# Altium schematic sheet Stage1A_Boost_controller.SchDoc
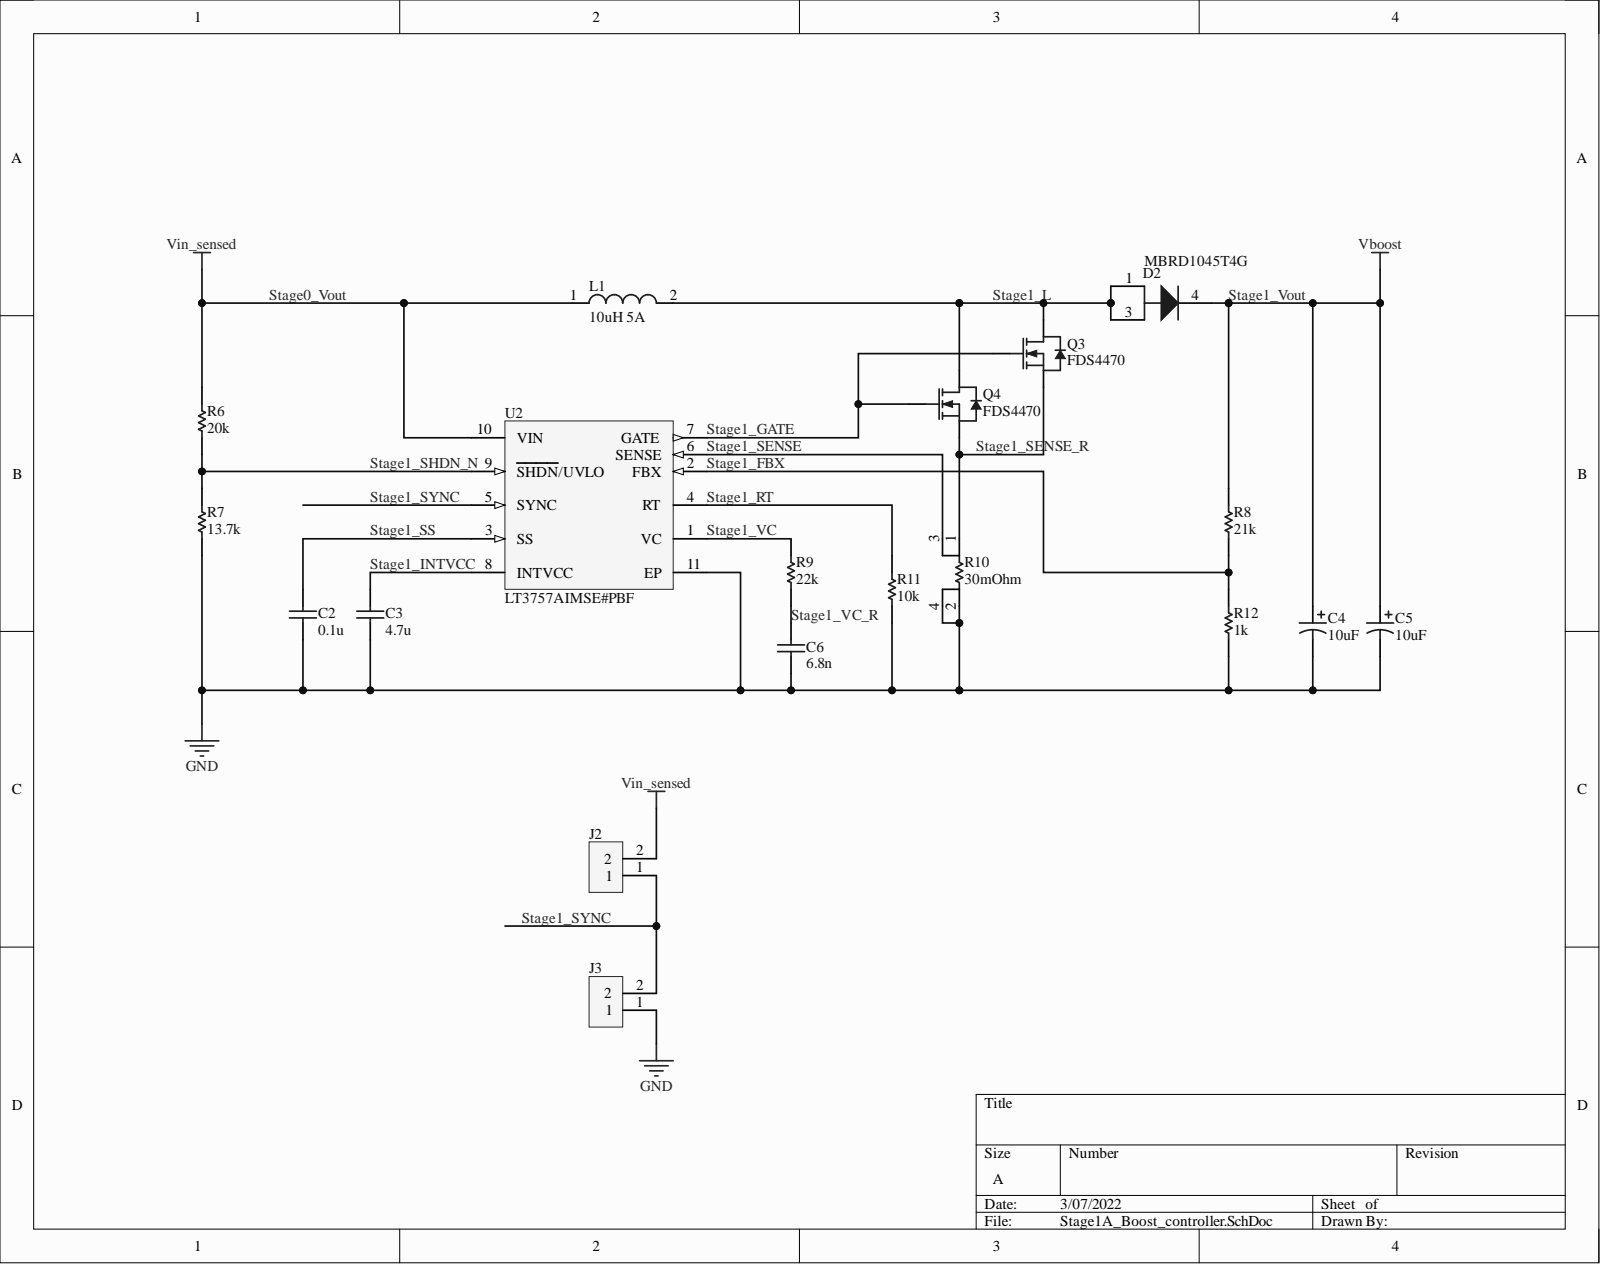
[diagram p0: Stage1A_Boost_controller.SchDoc]

## Parts
C2  0.1u  [PCB-0002-00735-1]
C3  4.7u  [CAPC2013X94X50ML20T25]
C4  10uF  [PCB-45uljczbzgwzo9m7ql45-1]
C5  10uF  [PCB-45uljczbzgwzo9m7ql45-1]
C6  6.8n  [CAPC2013X88X50NL20T25]
D2  MBRD1045T4G  [ONSC-DPAK-3-369C-01_V]
J2  (no value)  [PCB-omgsbkhp90n7lpri88og-1]
J3  (no value)  [PCB-omgsbkhp90n7lpri88og-1]
L1  10uH 5A  [WE-PD-L]
Q3  FDS4470  [PCB-vam1no1ldi8601k0q85a-1]
Q4  FDS4470  [PCB-vam1no1ldi8601k0q85a-1]
R6  20k  [RESC2013X55X40LL15T20]
R7  13.7k  [RESC2013X70X40LL20T20]
R8  21k  [RESC2013X70X40NL20T20]
R9  22k  [PCB-0002-00354-1]
R10  30mOhm  [PCB-e4z1ou8eehx9zfei5nig-1]
R11  10k  [RESC2013X55X40NL15T20]
R12  1k  [PCB-r6i24v81vhbjsehgeff4-1]
U2  LT3757AIMSE#PBF  [LT-MSE-10-EDP_N]

## Nets
GND: C2.1 C3.1 C4.2 C5.2 C6.1 J3.1 R7.1 R10.2 R10.4 R11.1 R12.1 U2.11(EP)
Stage0_Vout: J2.2 L1.1 R6.2 U2.10(VIN)
Stage1_FBX: R8.1 R12.2 U2.2(FBX)
Stage1_GATE: Q3.1 Q4.1 U2.7(GATE)
Stage1_INTVCC: C3.2 U2.8(INTVCC)
Stage1_L: D2.1 D2.3 L1.2 Q3.3 Q4.3
Stage1_RT: R11.2 U2.4(RT)
Stage1_SENSE: R10.3 U2.6(SENSE)
Stage1_SENSE_R: Q3.2 Q4.2 R10.1
Stage1_SHDN_N: R6.1 R7.2 U2.9(SHDN/UVLO)
Stage1_SS: C2.2 U2.3(SS)
Stage1_SYNC: J2.1 J3.2 U2.5(SYNC)
Stage1_VC: R9.2 U2.1(VC)
Stage1_VC_R: C6.2 R9.1
Stage1_Vout: C4.1 C5.1 D2.4 R8.2

## Library data of the parts
C2 = CMP-126393-3: CAP CER 0.1UF 50V 10% X7R 0805; Manufacturer Part Number: 08055C104KAZ2A; Capacitance: 1e-07 F; Case/Package (SI): 2012; Dielectric Material: Ceramic Multilayer; Lead-Free Status: Lead Free; Voltage Rating (DC): 50.0 V; Capacitance Tolerance: ±10%; Case/Package: 0805; Component Kind: Standard; Dielectric Characteristic: X7R; Library Name: My Personal Space; Mounting Style: Surface Mount; Number of Pins: 2; Octopart Url: https://octopart.com/08055c104kaz2a-avx+interconnect+%2F+elco-195624; OctopartID: aa35633d737b75f2; REACH SVHC Compliance: No SVHC; RoHS: Compliant; Size-Height: 0.00094 m; Size-Length: 0.00201 m; Size-Width: 0.00125 m
C3 = CMP-a5e66d676ec67a21-1: C Series 0805 4.7 uF 25 V ±10 % Tolerance X5R SMT Multilayer Ceramic Capacitor; Manufacturer Part Number: C2012X5R1E475K125AB; Capacitance: 4.70 µF; Capacitance Tolerance: ±10%; Dielectric Material: Ceramic Multilayer; Lead-Free Status: Lead Free; Voltage Rating (DC): 25.0 V; Case/Package: 2012; Component Kind: Standard; Dielectric Characteristic: X5R; Library Name: My Personal Space; Number of Pins: 2; Octopart URL: http://octopart.com/c2012x5r1e475k125ab-tdk-25947718; OctopartID: a5e66d676ec67a21; Packaging: Cut Tape (CT); REACH SVHC Compliance: No SVHC; RoHS: Compliant; Size-Length: 2.00 mm; Size-Thickness: 1.25 mm; Size-Width: 1.25 mm
C4 C5 = CMP-7610bd40367da9d7-6: Capacitor; Aluminum Electrolytic; Fc Radial Elec Cap 10uF 50V; Manufacturer Part Number: EEU-FC1H100L; Capacitance: 10.0 µF; Capacitance Tolerance: ±20%; Lead-Free Status: Lead Free; Voltage Rating (DC): 50.0 V; Component Kind: Standard; Dielectric Material: Aluminum Electrolytic; Library Name: My Personal Space; Lifecycle Status: Not Listed by Manufacturer; Mounting Style: Through Hole; Number of Pins: 2; Octopart URL: https://octopart.com/eeu-fc1h100l-panasonic-39478539; OctopartID: 7610bd40367da9d7; Operating Temperature: 105 °C (max); Packaging: Bulk; REACH SVHC Compliance: No SVHC; RoHS: Compliant; Size-Diameter: 5.00 mm; Size-Height: 11.0 mm; Size-Length: 11.0 mm
C6 = CMP-158700-2: CAP CER 6800PF 50V 5% NP0 0805; Manufacturer Part Number: C0805C682J5GACTU; Capacitance: 6.8e-09 F; Dielectric Characteristic: C0G/NP0; Dielectric Material: Ceramic; Lead-Free Status: Lead Free; Voltage Rating (DC): 50.0 V; Capacitance Tolerance: ±5%; Case/Package: 0805; Component Kind: Standard; Library Name: My Personal Space; Mounting Style: Surface Mount; Number of Pins: 2; Octopart Url: https://octopart.com/c0805c682j5gactu-kemet-12793218; OctopartID: 549c9d5544788b5b; Packaging: Cut Tape (CT); REACH SVHC Compliance: No SVHC; RoHS: Compliant
D2 = CMP-10976408-2: SWITCHMODE(TM) Schottky Power Rectifier, 3-Pin DPAK, Pb-Free, Tape and Reel; Current Rating: 10.0 A; Lead-Free Status: Lead Free; Polarity: Standard; Voltage Rating (DC): 45.0 V; Case/Package: DPAK; Component Kind: Standard; Halogen Free Status: Halogen Free; Library Name: My Personal Space; Lifecycle Status: Active; Manufacturer Part Number: MBRD1045T4G; Mounting Style: Surface Mount; Number of Pins: 3; Octopart Url: https://octopart.com/mbrd1045t4g-on+semiconductor-1229353; OctopartID: f02c393417632feb; Packaging: Tape; REACH SVHC Compliance: No SVHC; RoHS: Compliant
J2 J3 = CMP-748fbcb73d6bab48-30: Pitch 2.54 mm, Single Row, 2pins; Component Kind: Standard; Library Name: My Personal Space
L1 = CMP-912551-2: SMD-Shielded Power Inductor WE-PD, L = 10.0 µH; Manufacturer Part Number: 74477110; Inductance: 1e-05 H; Resistance: 0.018 Ω; Resonant Frequency: 21500000.0 Hz; Component Kind: Standard; Library Name: My Personal Space; Number of Pins: 2; Octopart Url: https://octopart.com/74477110-w%C3%BCrth+elektronik-8917130; OctopartID: 4fd586577a399012; Packaging: Cut Tape (CT); REACH SVHC Compliance: No SVHC; RoHS: Compliant; Self Resonant Frequency: 21500000.0 Hz
Q3 Q4 = CMP-cab003bfccd71577-2: FDS4470 Series 40 V 9 mOhm Surface Mount N-Channel PowerTrench Mosfet - SOIC-8; Breakdown Voltage (Drain to Source): -100.0 V; Continuous Drain Current (Ids): 12.5 A; Drain to Source Resistance (on) (Rds): 0.009 Ω; Drain to Source Voltage (Vds): 40.0 V; Gate Charge: 4.5e-08 C; Input Capacitance: 2.659e-09 F; Lead-Free Status: Lead Free; Power Dissipation: 2.5 W; Rise Time: 1.2e-08 s; Case/Package: SOIC; Component Kind: Standard; Current Rating: 12.5 A; Library Name: My Personal Space; Lifecycle Status: Active; Manufacturer Part Number: FDS4470; Mounting Style: Surface Mount; Number of Pins: 8; Octopart URL: http://octopart.com/fds4470-fairchild+semiconductor-336641; OctopartID: cab003bfccd71577; Packaging: Tape; Polarity: N-Channel; REACH SVHC Compliance: No SVHC; RoHS: Compliant; Voltage Rating (DC): 40.0 V
R6 = CMP-1953985-3: RES SMD 20K OHM 0.1% 1/8W 0805; Manufacturer Part Number: TNPW080520K0BEEN; Case/Package (SI): 2012; Lead-Free Status: Lead Free; Power Rating: 0.125 W; Resistance: 20000.0 Ω; Temperature Coefficient: 25.0 ppm/°C; Voltage Rating (DC): 150.0 V; Case/Package: 0805; Component Kind: Standard; Library Name: My Personal Space; Number of Pins: 2; Octopart Url: https://octopart.com/tnpw080520k0been-vishay+dale-40834551; OctopartID: 0bf6c0933b67d431; Packaging: Tape & Reel (TR); Resistance Tolerance: ±0.1%; RoHS: Compliant
R7 = CMP-3656557-2: Thick Film Resistors - SMD 0805 13.7Kohms 1% Tol; Manufacturer Part Number: ERJ-6ENF1372V; Case/Package (SI): 2012; Lead-Free Status: Lead Free; Power Rating: 0.125 W; Resistance: 13700.0 Ω; Voltage Rating (DC): 150.0 V; Case/Package: 0805; Component Kind: Standard; Library Name: My Personal Space; Lifecycle Status: Not Listed by Manufacturer; Mounting Style: Surface Mount; Octopart Url: https://octopart.com/erj-6enf1372v-panasonic-55422385; OctopartID: f5e53fa27d322a22; REACH SVHC Compliance: No SVHC; Resistance Tolerance: ±1%; RoHS: Compliant; Size-Height: 0.0006 m; Size-Length: 0.002 m; Size-Width: 0.00125 m
R8 = CMP-3655731-3: Thick Film Resistors - SMD 0805 21Kohms 1% Tol; Manufacturer Part Number: ERJ-6ENF2102V; Case/Package (SI): 2012; Lead-Free Status: Lead Free; Power Rating: 0.125 W; Resistance: 21000.0 Ω; Voltage Rating (DC): 150.0 V; Case/Package: 0805; Component Kind: Standard; Library Name: My Personal Space; Lifecycle Status: Not Listed by Manufacturer; Mounting Style: Surface Mount; Octopart Url: https://octopart.com/erj-6enf2102v-panasonic-55403689; OctopartID: d8f112b6993e0736; REACH SVHC Compliance: No SVHC; Resistance Tolerance: ±1%; RoHS: Compliant; Size-Height: 0.0006 m; Size-Length: 0.002 m; Size-Width: 0.00125 m
R9 = CMP-661aaa7c9094b9dd-1: Res General Purpose Thick Film 0805 22k Ohm 5% 1/8W ±100ppm/°C Molded Paper T/R; Manufacturer Part Number: CRGCQ0805J22K; Case/Package (SI): 2012; Power Rating: 125 mW; Resistance: 22.0 kΩ; Temperature Coefficient: -100 ppm/°C to 100 ppm/°C; Voltage Rating (DC): 150 V; Case/Package: 2012, 0805; Component Kind: Standard; Library Name: My Personal Space; Lifecycle Status: Not Listed by Manufacturer; Octopart URL: https://octopart.com/crgcq0805j22k-te+connectivity-91018216; OctopartID: 661aaa7c9094b9dd; Packaging: Tape & Reel (TR); Resistance Tolerance: ±5%; RoHS: Compliant
R10 = CMP-41418819abb09e86-1: Res Metal Alloy 1206 0.03 Ohm 0.5% 0.5W(1/2W) ±50ppm/C Epoxy Resin Pad SMD T/R; Manufacturer Part Number: LVK12R030DER; Case/Package (SI): 3216; Power Rating: 500 mW; Resistance: 30.0 mΩ; Temperature Coefficient: -50.0 ppm/°C to 50.0 ppm/°C; Case/Package: 3216, 1206; Component Kind: Standard; Library Name: My Personal Space; Mounting Style: Surface Mount; Number of Pins: 2; Octopart URL: https://octopart.com/lvk12r030der-ohmite-5427095; OctopartID: 41418819abb09e86; Operating Temperature: -40.0 °C to 125 °C; Packaging: Tape & Reel (TR), Cut Tape (CT); Resistance Tolerance: ±0.5%; RoHS: Compliant
R11 = CMP-1953953-14: RES SMD 1M OHM 0.1% 1/8W 0805; Manufacturer Part Number: TNPW080510K0BEEN; Case/Package (SI): 2012; Lead-Free Status: Lead Free; Power Rating: 0.125 W; Resistance: 7500000 Ω; Temperature Coefficient: 25.0 ppm/°C; Voltage Rating (DC): 150.0 V; Case/Package: 0805; Component Kind: Standard; Library Name: My Personal Space; Number of Pins: 2; OctopartID: 2ed9e58acfb9f11e; Packaging: Tape & Reel (TR); Resistance Tolerance: ±0.1%; RoHS: Compliant
R12 = CMP-6592ac89fd25ba55-2: Surface Mount Thin Film Resistor, AEC-Q200 ERA Series, 1 kohm, 125 mW, - 0.1%, 100 V; Manufacturer Part Number: ERA6AEB102V; Case/Package (SI): 2012; Lead-Free Status: Lead Free; Power Rating: 125 mW; Resistance: 1.00 kΩ; Voltage Rating (DC): 100 V; Case/Package: 0805; Component Kind: Standard; Library Name: My Personal Space; Lifecycle Status: Not Listed by Manufacturer; Mounting Style: Surface Mount; Number of Pins: 2; Octopart URL: http://octopart.com/era6aeb102v-panasonic-55396929; OctopartID: d6e61ca1b9c10921; Packaging: Cut Tape (CT), Tape & Reel (TR); REACH SVHC Compliance: No SVHC; Resistance Tolerance: ±0.1%; RoHS: Compliant; Size-Height: 500 µm; Size-Length: 2.00 mm; Size-Width: 1.25 mm
U2 = CMP-4366626-3: Boost, Flyback, SEPIC and Inverting Controller-Improved Load Transient, 10-pin SOP (MSE-10-EDP), -40 to 125 degC, Pb-Free; Lead-Free Status: Lead Free; Component Kind: Standard; Library Name: My Personal Space; Manufacturer Part Number: LT3757AIMSE#PBF; Octopart Url: https://octopart.com/lt3757aimse%23pbf-linear+technology-24152687; OctopartID: 3c72f408937be3b5; RoHS: Compliant
